# Altium schematic sheet Sheet3.SchDoc(Sheet3)
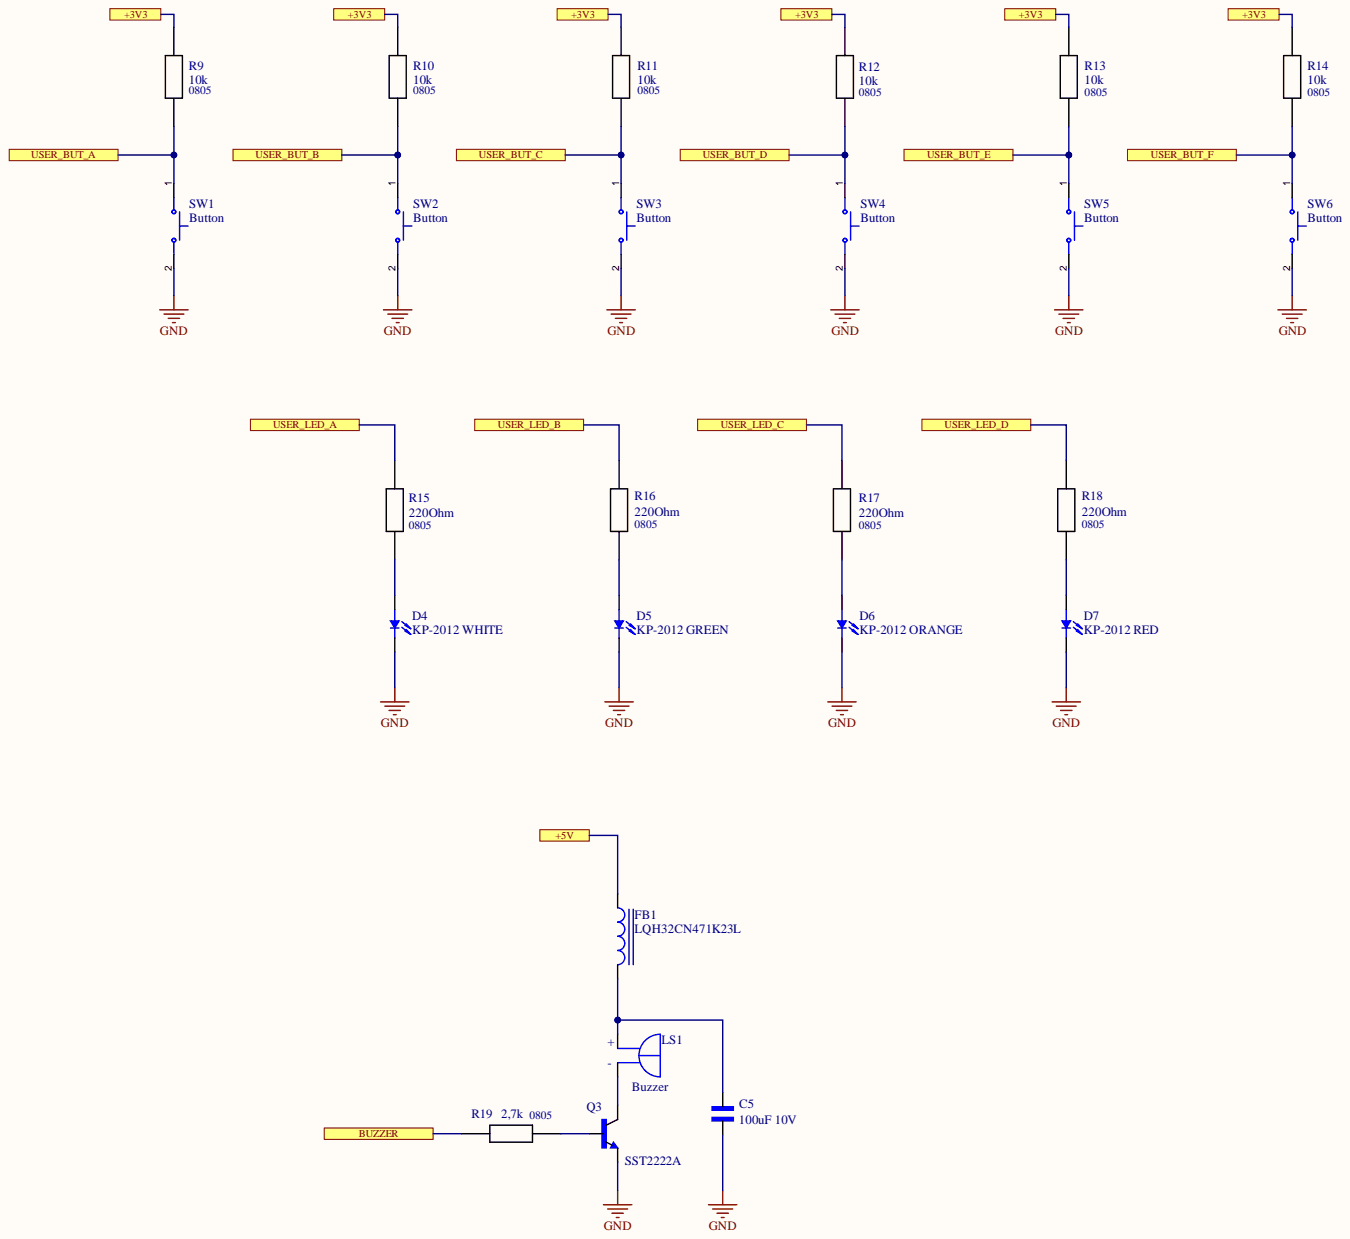
[diagram p0: Sheet3.SchDoc]

## Parts
C5  100uF  [SMD 1210 CAP]
D4  KP-2012 WHITE  value KP-2012  [SMD 0805 LED-Y]
D5  KP-2012 GREEN  value KP-2012  [SMD 0805 LED-Y]
D6  KP-2012 ORANGE  value KP-2012  [SMD 0805 LED-Y]
D7  KP-2012 RED  value KP-2012  [SMD 0805 LED-Y]
FB1  LQH32CN471K23L  [SMD 1210 LQH]
LS1  Buzzer  [XDCR_KSSG13J12-N]
Q3  SST2222A  [SOT-23-3]
R9  10k  Case: 0805  [SMD 0805]
R10  10k  Case: 0805  [SMD 0805]
R11  10k  Case: 0805  [SMD 0805]
R12  10k  Case: 0805  [SMD 0805]
R13  10k  Case: 0805  [SMD 0805]
R14  10k  Case: 0805  [SMD 0805]
R15  220Ohm  Case: 0805  [SMD 0805]
R16  220Ohm  Case: 0805  [SMD 0805]
R17  220Ohm  Case: 0805  [SMD 0805]
R18  220Ohm  Case: 0805  [SMD 0805]
R19  2,7k  Case: 0805  [SMD 0805]
SW1  Button  [SW_KSR221GLFS]
SW2  Button  [SW_KSR221GLFS]
SW3  Button  [SW_KSR221GLFS]
SW4  Button  [SW_KSR221GLFS]
SW5  Button  [SW_KSR221GLFS]
SW6  Button  [SW_KSR221GLFS]

## Ports
+3V3, +5V, BUZZER, USER_BUT_A, USER_BUT_B, USER_BUT_C, USER_BUT_D, USER_BUT_E, USER_BUT_F, USER_LED_A, USER_LED_B, USER_LED_C, USER_LED_D

## Nets
GND: C5.1 D4.C D5.C D6.C D7.C Q3.2 SW1.2 SW2.2 SW3.2 SW4.2 SW5.2 SW6.2
+3V3: R9.1 R10.1 R11.1 R12.1 R13.1 R14.1 ; port +3V3
+5V: FB1.1 ; port +5V
BUZZER: R19.1 ; port BUZZER
USER_BUT_A: R9.2 SW1.1 ; port USER_BUT_A
USER_BUT_B: R10.2 SW2.1 ; port USER_BUT_B
USER_BUT_C: R11.2 SW3.1 ; port USER_BUT_C
USER_BUT_D: R12.2 SW4.1 ; port USER_BUT_D
USER_BUT_E: R13.2 SW5.1 ; port USER_BUT_E
USER_BUT_F: R14.2 SW6.1 ; port USER_BUT_F
USER_LED_A: R15.1 ; port USER_LED_A
USER_LED_B: R16.1 ; port USER_LED_B
USER_LED_C: R17.1 ; port USER_LED_C
USER_LED_D: R18.1 ; port USER_LED_D
NetC5_2: C5.2 FB1.2 LS1.P
NetD4_A: D4.A R15.2
NetD5_A: D5.A R16.2
NetD6_A: D6.A R17.2
NetD7_A: D7.A R18.2
NetLS1_N: LS1.N Q3.3
NetQ3_1: Q3.1 R19.2

## Library data of the parts
C5 = CL32B106KLJNNNE: 100uF 10V X5R +-20%; Manufacturer: SAMSUNG ELECTRO-MECHANICS; Case: 1210; Component Kind: Standard; HelpURL: https://store.comet.bg/Catalogue/Product/18382/; Library Name: CAN_Library.IntLib; Value: 100uF; Voltage: 10V
D4-D7 = KP-2012: LED 2.0x1.25mm, 50-160 mcd@20mA, W.Clear, 120°; MF: Rohm Semiconductor; MP: SMLMN2WB1CW1C; Availability: Unavailable; Component Kind: Standard; Library Name: CAN_Library.IntLib; Package: 0805 Rohm Semiconductor; Price: None; SnapEDA_Link: https://www.snapeda.com/parts/SMLMN2WB1CW1C/Rohm/view-part/3217279/?ref=snap
FB1 = LQH32CN471K23L: 470uH 19Ohm 60mA 10%    47uH 1.3Ohm 170mA 10%; MF: MURATA ELECTRONICS; Component Kind: Standard; Library Name: CAN_Library.IntLib; MP: LQH32CN471K; Package: 1210; URL 47uH: https://store.comet.bg/Catalogue/Product/48001/; URL 470uH: https://store.comet.bg/Catalogue/Product/48004/; Value: LQH32CN471K23L
LS1 = KSSG13J12-N: Availability: Unavailable; Component Kind: Standard; Library Name: CAN_Library.IntLib; MP: KSSG13J12-N; Package: None; Price: None; Value: Buzzer
Q3 = SST2222A: Transistor NPN 40V 600mA 300MHz 350mW; Manufacturer: ROHM Semiconductor; Case: SOT-23-3 SST3; Component Kind: Standard; HelpURL: https://store.comet.bg/Catalogue/Product/23715/; Library Name: CAN_Library.IntLib; Value: SST2222A
R9-R19 = Resistor_1: RES; Component Kind: Standard; HelpURL: https://store.comet.bg/Catalogue/RES/Resistors/#eyJpcHAiOiIxMDAiLCJtIjoiIn0%3D; Library Name: CAN_Library.IntLib
  R9-R14: Value: 10k
  R15-R18: Value: 220Ohm
  R19: Value: 2,7k
SW1-SW6 = KSR221GLFS: Tactile Switch 2p SPST-NO 50mA/32V 6x3.8x2.5mm; MF: C&K; Component Kind: Standard; Library Name: CAN_Library.IntLib; MP: KSR221GLFS; Package: 6x3.8x2.5mm; URL: https://store.comet.bg/Catalogue/Product/17512/; Value: Button
